# Altium schematic sheet Main.SchDoc
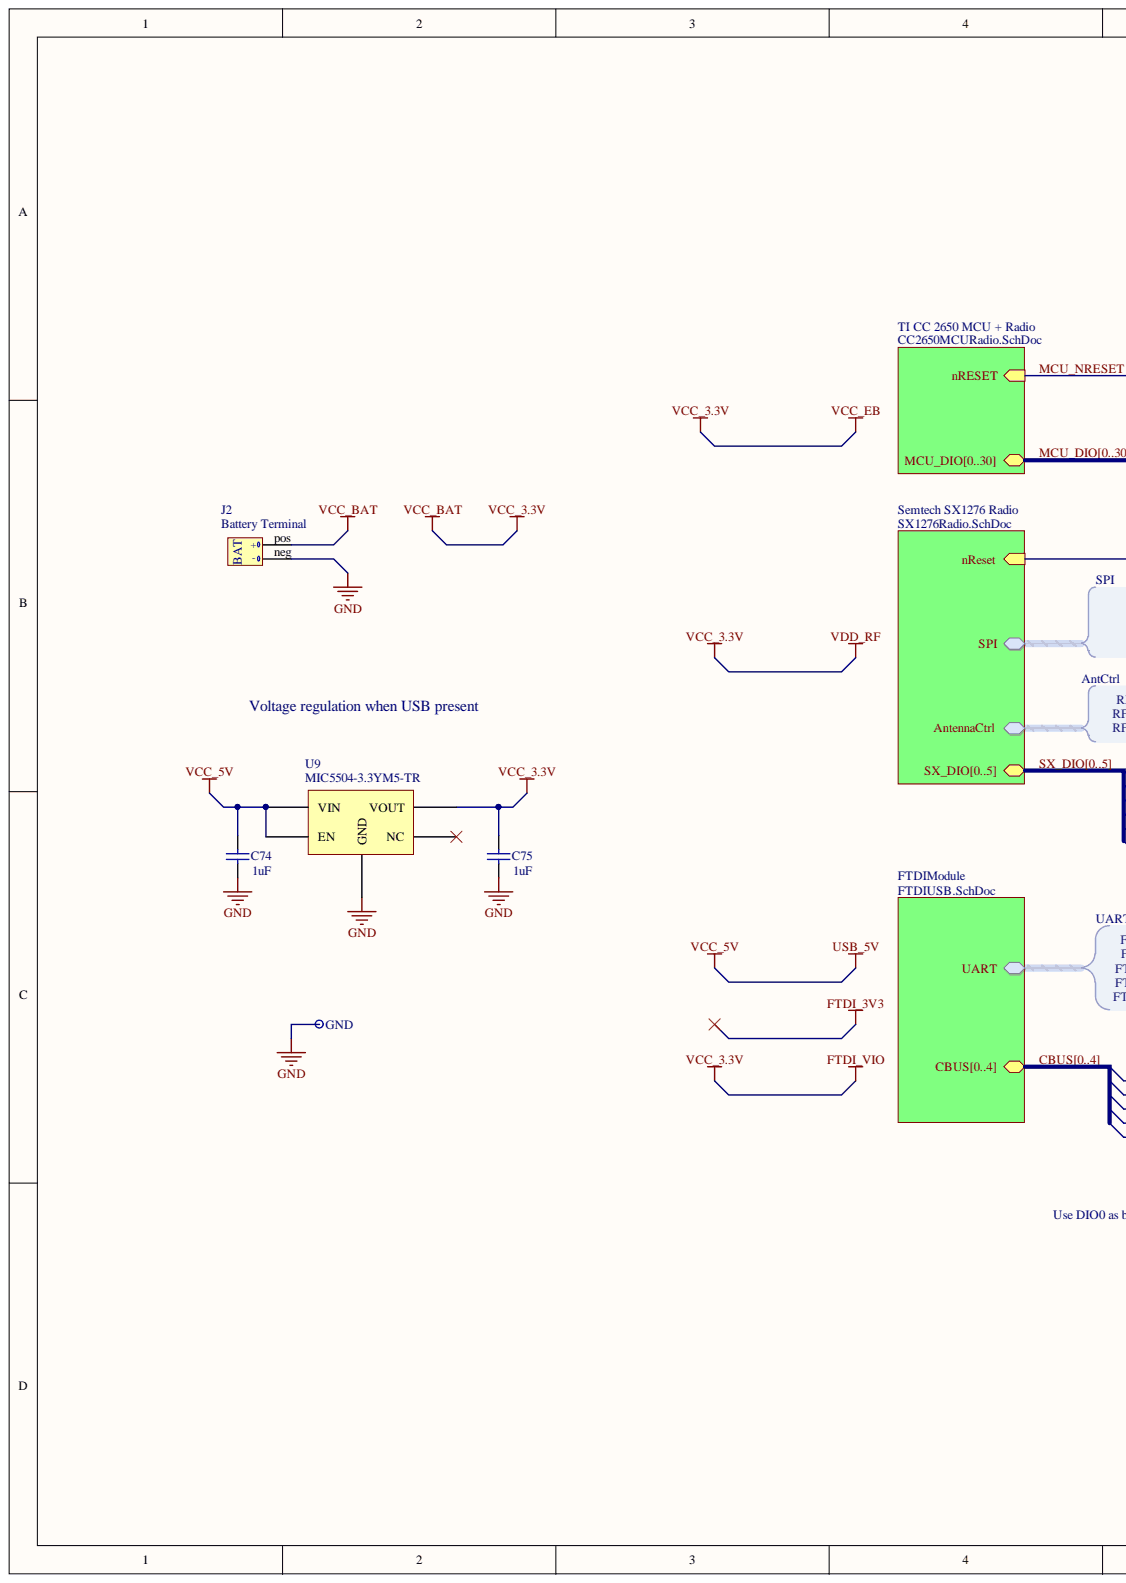
[diagram p0: Main.SchDoc, left]
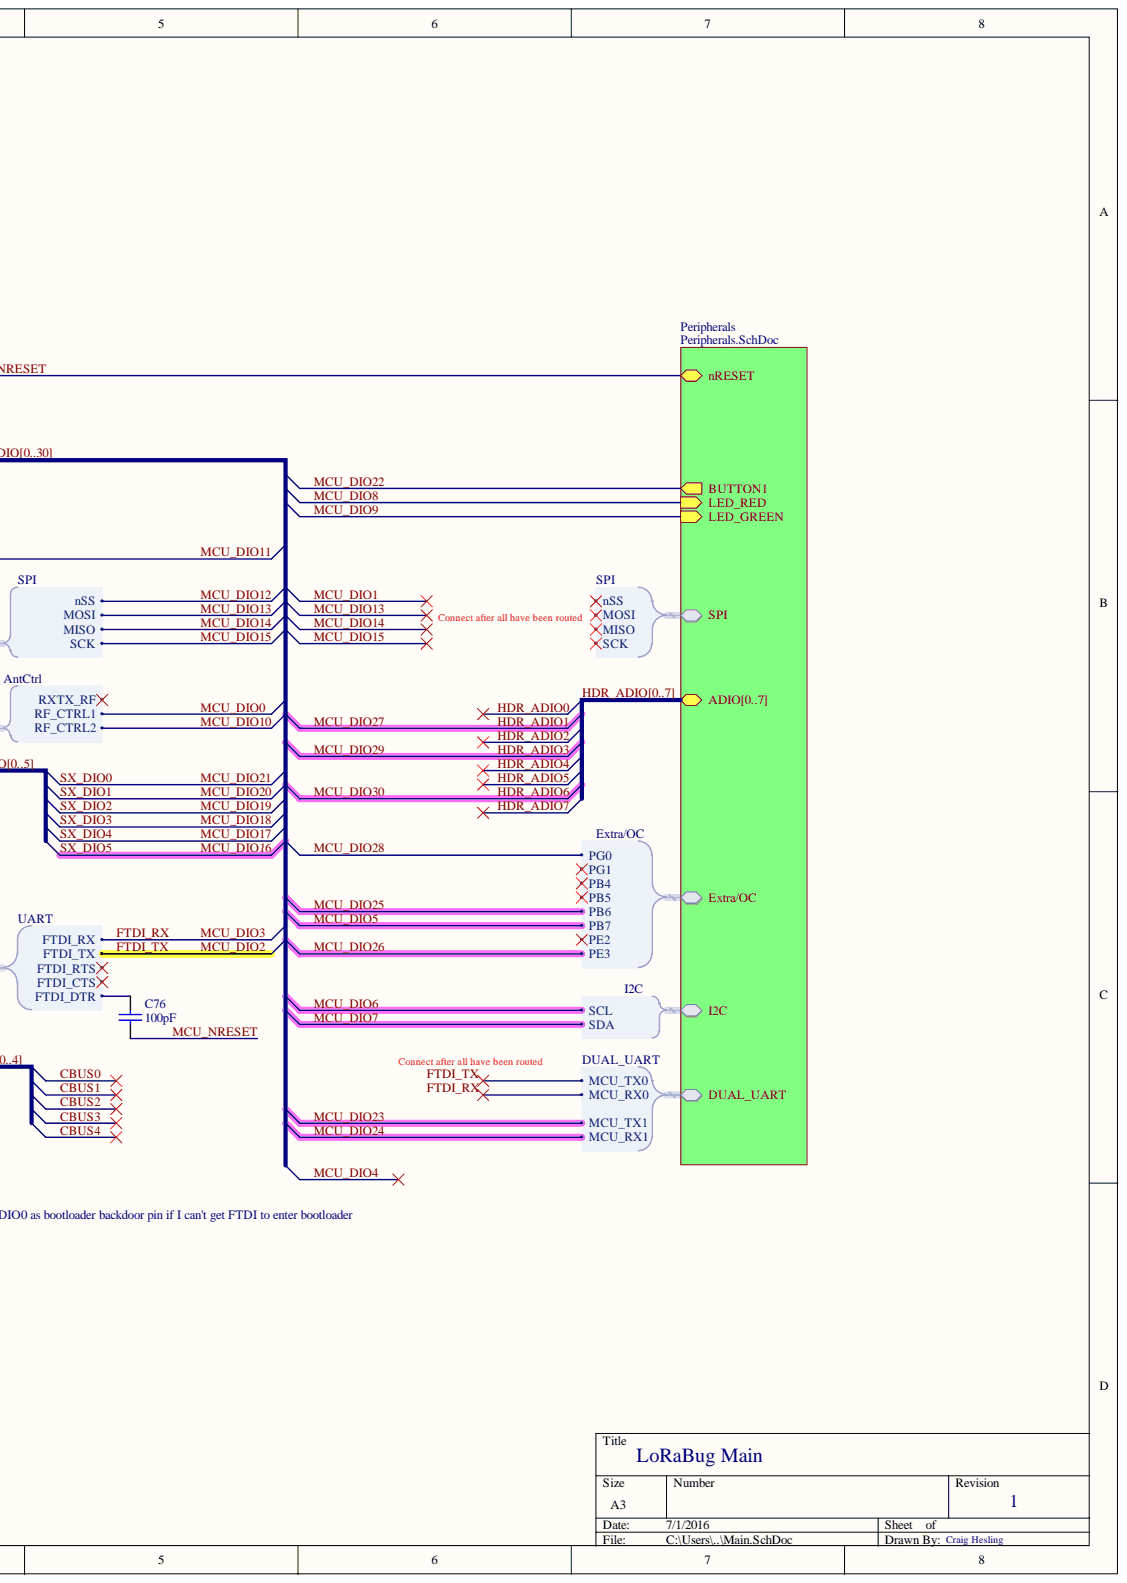
[diagram p1: Main.SchDoc, right]

## Parts
C74  value 1uF  [1608[0603]]  (hidden comment: Cap Semi)
C75  value 1uF  [1608[0603]]  (hidden comment: Cap Semi)
C76  value 100pF  [0402]  (hidden comment: Cap Semi)
GND  Test Pin  [pin_1mm]  (value not drawn)
J2  Battery Terminal  [Battery Terminal]
U9  MIC5504-3.3YM5-TR  [MIC5504-3.3YM5-TR]

## Nets
FTDI_VIO: C75.2 J2.pos U9.VOUT
GND: C74.1 C75.1 GND.1 J2.neg U9.GND
USB_5V: C74.2 U9.EN U9.VIN
MCU_NRESET: C76.1
NetC76_2: C76.2
NetU9_NC: U9.NC
ANTENNACTRL.RXTX_RF:
CBUS0:  [also labelled CBUS]
CBUS1:  [also labelled CBUS]
CBUS2:  [also labelled CBUS]
CBUS3:  [also labelled CBUS]
CBUS4:  [also labelled CBUS]
DUAL_UART.MCU_RX0:
DUAL_UART.MCU_TX0:
EXTRA/OC.PB4:
EXTRA/OC.PB5:
EXTRA/OC.PE2:
EXTRA/OC.PG1:
FTDI_3V3:
HDR_ADIO0:  [also labelled HDR_ADIO]
HDR_ADIO1:  [also labelled HDR_ADIO]
HDR_ADIO2:  [also labelled HDR_ADIO]
HDR_ADIO3:  [also labelled HDR_ADIO]
HDR_ADIO4:  [also labelled HDR_ADIO]
HDR_ADIO5:  [also labelled HDR_ADIO]
HDR_ADIO6:  [also labelled HDR_ADIO]
HDR_ADIO7:  [also labelled HDR_ADIO]
MCU_DIO0:  [also labelled MCU_DIO]
MCU_DIO1:  [also labelled MCU_DIO]
MCU_DIO4:  [also labelled MCU_DIO]
MCU_DIO5:  [also labelled MCU_DIO]
MCU_DIO6:  [also labelled MCU_DIO]
MCU_DIO7:  [also labelled MCU_DIO]
MCU_DIO8:  [also labelled MCU_DIO]
MCU_DIO9:  [also labelled MCU_DIO]
MCU_DIO10:  [also labelled MCU_DIO]
MCU_DIO11:  [also labelled MCU_DIO]
MCU_DIO12:  [also labelled MCU_DIO]
MCU_DIO13:  [also labelled MCU_DIO]
MCU_DIO14:  [also labelled MCU_DIO]
MCU_DIO15:  [also labelled MCU_DIO]
MCU_DIO16:  [also labelled MCU_DIO]
MCU_DIO17:  [also labelled MCU_DIO]
MCU_DIO18:  [also labelled MCU_DIO]
MCU_DIO19:  [also labelled MCU_DIO]
MCU_DIO20:  [also labelled MCU_DIO]
MCU_DIO21:  [also labelled MCU_DIO]
MCU_DIO22:  [also labelled MCU_DIO]
MCU_DIO23:  [also labelled MCU_DIO]
MCU_DIO24:  [also labelled MCU_DIO]
MCU_DIO25:  [also labelled MCU_DIO]
MCU_DIO26:  [also labelled MCU_DIO]
MCU_DIO28:  [also labelled MCU_DIO]
SPI.MISO:
SPI.MOSI:
SPI.NSS:
SPI.SCK:
SX_DIO[0..5]:
UART.FTDI_CTS:
UART.FTDI_RTS:

## Library data of the parts
C74-C76 = Cap Semi: Capacitor (Semiconductor SIM Model); Component Kind: Standard; LatestRevisionDate: 29-May-2009; LatestRevisionNote: IPC-7351 Footprint Added.; Library Name: Miscellaneous Devices.IntLib; PackageDescription: Chip Capacitor; PackageReference: C1206; Published: 8-Jun-2000; Signal Integrity: Cap Semi; Simulation: CAP; Publisher: Altium Limited
GND = test_pin: Component Kind: Standard; Description: Test Pin; LatestRevisionDate: 05-oct-2007  -  Semtech; Library Name: SX1276_e268v01a.SchLib
J2 = Battery Terminal: Component Kind: Standard; Library Name: ExtraComponents.SchLib
U9 = MIC5504-3.3YM5-TR: Component Kind: Standard; Description: MIC5504-3.3YM5-TR; Supplier 1: Digi-Key; Supplier 2: Digi-Key; Supplier Part Number 1: 576-4764-2-ND; Supplier Part Number 2: 576-4764-6-ND; Library Name: ExtraComponents.SchLib
